# Altium schematic sheet STM32F405开发板v2.2_通信接口.SchDoc
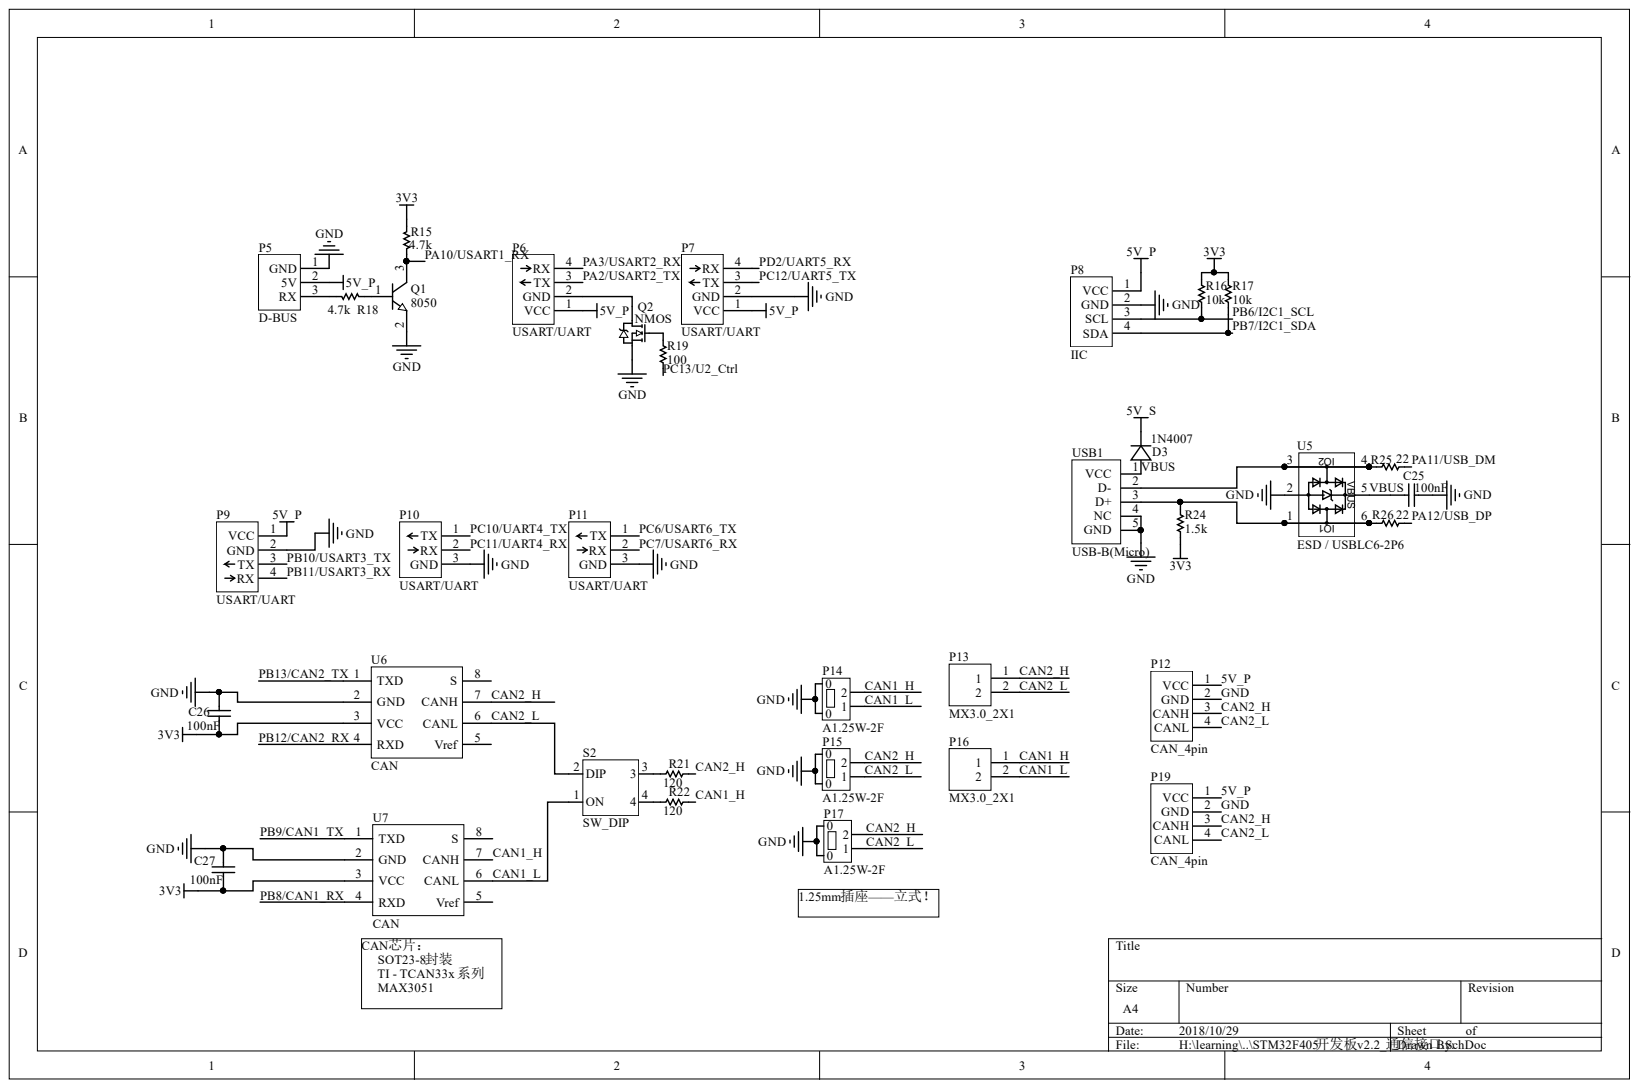
[diagram p0: STM32F405开发板v2.2_通信接口.SchDoc]

## Parts
C25  100nF  [0402]
C26  100nF  [0402]
C27  100nF  [0402]
D3  1N4007  [SOD-323-]
P5  D-BUS  [HY2.00MM 3x1立式]
P6  USART/UART  [HY2.00MM 4X1立式]
P7  USART/UART  [HY2.00MM 4X1立式]
P8  IIC  [HY2.00MM 4X1立式]
P9  USART/UART  [HY2.00MM 4X1立式]
P10  USART/UART  [HY2.00MM 3x1立式]
P11  USART/UART  [HY2.00MM 3x1立式]
P12  CAN_4pin  [HY2.00MM 4X1立式]
P13  MX3.0_2X1  [MX3.0MM 2X1AW]
P14  A1.25W-2F  [GH1.25_立式]
P15  A1.25W-2F  [GH1.25_立式]
P16  MX3.0_2X1  [MX3.0MM 2X1AW]
P17  A1.25W-2F  [GH1.25_立式]
P19  CAN_4pin  [HY2.00MM 4X1立式]
Q1  8050  [SOT-323]
Q2  NMOS  [SOT-23-3]
R15  4.7k  [0402R]
R16  10k  [0402R]
R17  10k  [0402R]
R18  4.7k  [0402R]
R19  100  [0402R]
R21  120  [0603R]
R22  120  [0603R]
R24  1.5k  [0402R]
R25  22  [0402R]
R26  22  [0402R]
S2  SW_DIP  [SW_DIP_2x2]
U5  ESD / USBLC6-2P6  [SOT-666]
U6  CAN  [SOT-23-8]
U7  CAN  [SOT-23-8]
USB1  USB-B(Micro)  [USB-micro_A]

## Nets
3V3: C26.1 C27.1 R15.2 R16.2 R17.2 R24.1 U6.3(VCC) U7.3(VCC)
5V_S: D3.2
5V_P: P5.2 P6.1(VCC) P7.1(VCC) P8.1(VCC) P9.1(VCC) P12.1(VCC) P19.1(VCC)
CAN1_H: P14.2 P16.1 R22.1 U7.7(CANH)
CAN1_L: P14.1 P16.2 S2.1(ON) U7.6(CANL)
CAN2_H: P12.3(CANH) P13.1 P15.2 P17.2 P19.3(CANH) R21.1 U6.7(CANH)
CAN2_L: P12.4(CANL) P13.2 P15.1 P17.1 P19.4(CANL) S2.2(DIP) U6.6(CANL)
GND: C25.2 C26.2 C27.2 P5.1 P7.2(GND) P8.2(GND) P9.2(GND) P10.3 P11.3 P12.2(GND) P14.0 P15.0 P17.0 P19.2(GND) Q1.2 Q2.2 U5.2 U6.2(GND) U7.2(GND) USB1.4(NC) USB1.5(GND)
PA2/USART2_TX: P6.3(TX)
PA3/USART2_RX: P6.4(RX)
PA10/USART1_RX: Q1.3 R15.1
PA11/USB_DM: R25.1
PA12/USB_DP: R26.1
PB6/I2C1_SCL: P8.3(SCL) R16.1
PB7/I2C1_SDA: P8.4(SDA) R17.1
PB8/CAN1_RX: U7.4(RXD)
PB9/CAN1_TX: U7.1(TXD)
PB10/USART3_TX: P9.3(TX)
PB11/USART3_RX: P9.4(RX)
PB12/CAN2_RX: U6.4(RXD)
PB13/CAN2_TX: U6.1(TXD)
PC6/USART6_TX: P11.1
PC7/USART6_RX: P11.2
PC10/UART4_TX: P10.1
PC11/UART4_RX: P10.2
PC12/UART5_TX: P7.3(TX)
PC13/U2_CTRL: R19.1
PD2/UART5_RX: P7.4(RX)
VBUS: C25.1 D3.1 U5.5 USB1.1(VCC)
NetP5_3: P5.3 R18.2
NetP6_2: P6.2(GND) Q2.3
NetQ1_1: Q1.1 R18.1
NetQ2_1: Q2.1 R19.2
NetR21_2: R21.2 S2.3
NetR22_2: R22.2 S2.4
NetR24_2: R24.2 R26.2 U5.1 U5.6 USB1.3(D+)
NetR25_2: R25.2 U5.3 U5.4 USB1.2(D-)

Not connected: U6 5(Vref) 8(S); U7 5(Vref) 8(S)

## Library data of the parts
C25-C27 = Cap: Capacitor; Value: 100pF; Component Kind: Standard; LatestRevisionDate: 17-Jul-2002; LatestRevisionNote: Re-released for DXP Platform.; Library Name: MyComponents_v2.4.IntLib; PackageDescription: Radial Cap, Thru-Hole; 2 Leads; 0.3 in Pin Spacing; PackageReference: RAD-0.3; Published: 8-Jun-2000; Signal Integrity: Cap; Simulation: CAP; Publisher: Altium Limited
D3 = Diode: Default Diode; Code_JEDEC: DO-214-AB; Component Kind: Standard; LatestRevisionDate: 17-Jul-2002; LatestRevisionNote: Re-released for DXP Platform.; Library Name: MyComponents_v2.4.IntLib; PackageDescription: DO-214-AB/SMC; 2 C-Bend Leads; Body 7.9 x 5.9 mm, inc. leads (LxW); PackageReference: SMC; PackageVersion: Sep-1996; Published: 8-Jun-2000; Signal Integrity: Diode; Simulation: DIODE; Publisher: Altium Limited
P6 P7 P9 = UART_4pin: 带电源串口; Component Kind: Standard; Library Name: MyComponents_v2.4.IntLib
P10 P11 = UART_3pin: Component Kind: Standard; Library Name: MyComponents_v2.4.IntLib
P12 P19 = CAN_4pin: CAN 4pin，带5V电源，HY2.0-4A; Component Kind: Standard; Library Name: MyComponents_v2.4.IntLib
P14 P15 P17 = GH1.25-2P: Component Kind: Standard; Library Name: MyComponents_v2.4.IntLib
Q1 = NPN: NPN Bipolar Transistor; Code_JEDEC: TO-226-AA; Component Kind: Standard; LatestRevisionDate: 17-Jul-2002; LatestRevisionNote: Re-released for DXP Platform.; Library Name: MyComponents_v2.4.IntLib; PackageDescription: TO, Flat Index; 3 In-Line, Axial Leads; Body Dia. 4.8mm; Leads 0.55 x 0.51 mm (max); PackageReference: TO-226-AA; Published: 8-Jun-2000; Signal Integrity: NPN; Simulation: NPN; Publisher: Altium Limited
Q2 = NMOS(SOT23): N-Channel Power MOSFET; Note: Pin 2 is electrically connected to pin 4.; Component Kind: Standard; ComponentLink1Description: Manufacturer Link; ComponentLink1URL: http://www.irf.com/; DatasheetVersion: PD-9.814; LatestRevisionDate: 17-Jul-2002; LatestRevisionNote: Re-released for DXP Platform.; Library Name: MyComponents_v2.4.IntLib; PackageDescription: TO, Thru-Hole, Vertical, Heatsink Mounted; 3 In-Line Leads; Pitch 2.54 mm; PackageReference: TO-220AB; PackageVersion: Feb-1997; Published: 8-Jun-2000; Publisher: Altium Limited
R15-R19 R21 R22 R24-R26 = Res1: Resistor; Value: 1K; Component Kind: Standard; LatestRevisionDate: 17-Jul-2002; LatestRevisionNote: Re-released for DXP Platform.; Library Name: MyComponents_v2.4.IntLib; PackageDescription: Axial Device, Thru-Hole; 2 Leads; 0.3 in Pin Spacing; PackageReference: AXIAL-0.3; Published: 8-Jun-2000; Signal Integrity: Res; Simulation: RESISTOR; Publisher: Altium Limited
U5 = USBLC6-2: 2 data-line protection ,Protects VBUS; Component Kind: Standard; Library Name: MyComponents_v2.4.IntLib
U6 U7 = TJA1050: Component Kind: Standard; Library Name: MyComponents_v2.4.IntLib
USB1 = USB-micro: Component Kind: Standard; Library Name: MyComponents_v2.4.IntLib
P5 = D-BUS: Component Kind: Standard; Library Name: MyComponents_v2.4.IntLib
P8 = IIC: Component Kind: Standard; Library Name: MyComponents_v2.4.IntLib
P13 P16 = MX3.0_2X1: Component Kind: Standard; Library Name: MyComponents_v2.4.IntLib
S2 = SW_DIP: Component Kind: Standard; Library Name: MyComponents_v2.4.IntLib
